# Altium schematic sheet Resistor Bank.SchDoc
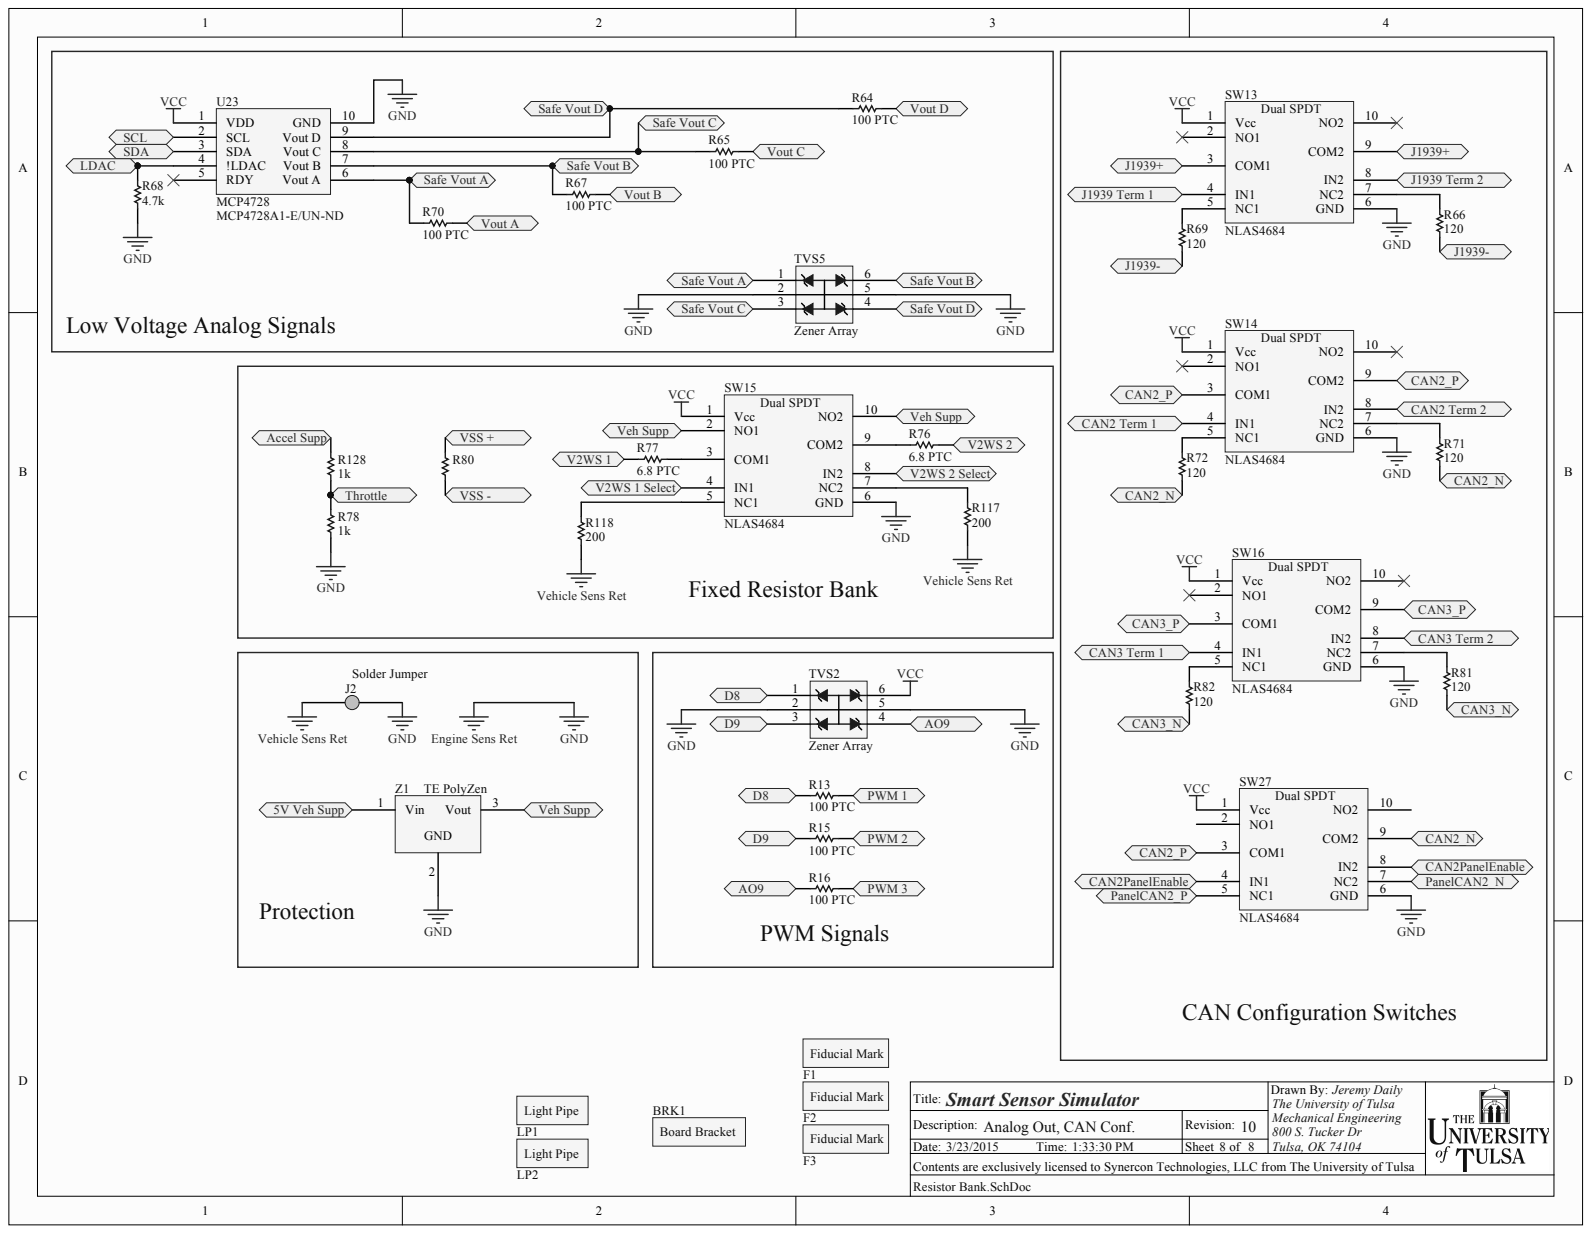
[diagram p0: Resistor Bank.SchDoc]

## Parts
BRK1  Bracket  [Keystone 7774]
F1  Fiducials  [Fiducial]  (value not drawn)
F2  Fiducials  [Fiducial]  (value not drawn)
F3  Fiducials  [Fiducial]  (value not drawn)
J2  Solder Jumper  [Solder Jumper]
LP1  Light Pipe  [Light Pipe]
LP2  Light Pipe  [Light Pipe]
R13  100 PTC  [0603]
R15  100 PTC  [0603]
R16  100 PTC  [0603]
R64  100 PTC  [0603]
R65  100 PTC  [0603]
R66  120  [0805]
R67  100 PTC  [0603]
R68  4.7k  [0805]
R69  120  [0805]
R70  100 PTC  [0603]
R71  120  [0805]
R72  120  [0805]
R76  6.8 PTC  [0805]
R77  6.8 PTC  [0805]
R78  1k  [0603]
R80  1.5k  [0603]  (value not drawn)
R81  120  [0805]
R82  120  [0805]
R117  200  [0805]
R118  200  [0805]
R128  1k  [0603]
SW13  NLAS4684  [ONSC-MICRO-10-846B-03_V]
SW14  NLAS4684  [ONSC-MICRO-10-846B-03_V]
SW15  NLAS4684  [ONSC-MICRO-10-846B-03_V]
SW16  NLAS4684  [ONSC-MICRO-10-846B-03_V]
SW27  NLAS4684  [ONSC-MICRO-10-846B-03_V]
TVS2  Zener Array  [SOT23-6]
TVS5  Zener Array  [SOT23-6]
U23  MCP4728  Supplier Part Number 1: MCP4728A1-E/UN-ND  [MSOP-UN10_M]
Z1  TE PolyZen  [ZEN LS]

## Ports
5V VEH SUPP, ACCEL SUPP, AO9, CAN2 TERM 1, CAN2 TERM 2, CAN2_N, CAN2_P, CAN2PANELENABLE, CAN3 TERM 1, CAN3 TERM 2, CAN3_N, CAN3_P, D8, D9, J1939 TERM 1, J1939 TERM 2, J1939+, J1939-, LDAC, PANELCAN2_N, PANELCAN2_P, PWM 1, PWM 2, PWM 3, SAFE VOUT A, SAFE VOUT B, SAFE VOUT C, SAFE VOUT D, SCL, SDA, THROTTLE, V2WS 1, V2WS 1 SELECT, V2WS 2, V2WS 2 SELECT, VEH SUPP, VOUT A, VOUT B, VOUT C, VOUT D, VSS +, VSS -

## Nets
ENGINE SENS RET: J2.1 R68.1 R78.1 SW13.6(GND) SW14.6(GND) SW15.6(GND) SW16.6(GND) SW27.6(GND) TVS2.2 TVS2.5 TVS5.2 TVS5.5 U23.10(GND) Z1.2
VCC: SW13.1(Vcc) SW14.1(Vcc) SW15.1(Vcc) SW16.1(Vcc) SW27.1(Vcc) TVS2.6 U23.1(VDD)
VEHICLE SENS RET: J2.2 R117.1 R118.1
AO9: R16.2 TVS2.4 ; port AO9
CAN2_N: R71.1 R72.1 SW27.9(COM2) ; port CAN2_N
CAN2_P: SW14.3(COM1) SW14.9(COM2) SW27.3(COM1) ; port CAN2_P
CAN3_N: R81.1 R82.1 ; port CAN3_N
CAN3_P: SW16.3(COM1) SW16.9(COM2) ; port CAN3_P
D8: R13.2 TVS2.1 ; port D8
D9: R15.2 TVS2.3 ; port D9
LDAC: R68.2 U23.4(!LDAC) ; port LDAC
PWM 1: R13.1 ; port PWM 1
PWM 2: R15.1 ; port PWM 2
PWM 3: R16.1 ; port PWM 3
SCL: U23.2(SCL) ; port SCL
SDA: U23.3(SDA) ; port SDA
V2WS 1: R77.2 ; port V2WS 1
V2WS 2: R76.1 ; port V2WS 2
NetR64_1: R64.1 ; port VOUT D
NetR64_2: R64.2 TVS5.4 U23.9(Vout_D) ; port SAFE VOUT D
NetR65_1: R65.1 ; port VOUT C
NetR65_2: R65.2 TVS5.3 U23.8(Vout_C) ; port SAFE VOUT C
NetR66_1: R66.1 R69.1
NetR66_2: R66.2 SW13.7(NC2)
NetR67_1: R67.1 ; port VOUT B
NetR67_2: R67.2 TVS5.6 U23.7(Vout_B) ; port SAFE VOUT B
NetR69_2: R69.2 SW13.5(NC1)
NetR70_1: R70.1 ; port VOUT A
NetR70_2: R70.2 TVS5.1 U23.6(Vout_A) ; port SAFE VOUT A
NetR71_2: R71.2 SW14.7(NC2)
NetR72_2: R72.2 SW14.5(NC1)
NetR76_2: R76.2 SW15.9(COM2)
NetR77_1: R77.1 SW15.3(COM1)
NetR78_2: R78.2 R128.1 ; port THROTTLE
NetR80_1: R80.1
NetR80_2: R80.2
NetR81_2: R81.2 SW16.7(NC2)
NetR82_2: R82.2 SW16.5(NC1)
NetR117_2: R117.2 SW15.7(NC2)
NetR118_2: R118.2 SW15.5(NC1)
NetR128_2: R128.2 ; port ACCEL SUPP
NetSW13_3: SW13.3(COM1) SW13.9(COM2)
NetSW13_4: SW13.4(IN1) ; port J1939 TERM 1
NetSW13_8: SW13.8(IN2) ; port J1939 TERM 2
NetSW14_4: SW14.4(IN1) ; port CAN2 TERM 1
NetSW14_8: SW14.8(IN2) ; port CAN2 TERM 2
NetSW15_2: SW15.2(NO1) SW15.10(NO2) Z1.3 ; port VEH SUPP
NetSW15_4: SW15.4(IN1) ; port V2WS 1 SELECT
NetSW15_8: SW15.8(IN2) ; port V2WS 2 SELECT
NetSW16_4: SW16.4(IN1) ; port CAN3 TERM 1
NetSW16_8: SW16.8(IN2) ; port CAN3 TERM 2
NetSW27_4: SW27.4(IN1) SW27.8(IN2) ; port CAN2PANELENABLE
NetSW27_5: SW27.5(NC1) ; port PANELCAN2_P
NetSW27_7: SW27.7(NC2) ; port PANELCAN2_N
NetZ1_1: Z1.1 ; port 5V VEH SUPP

Not connected: SW13 2(NO1) 10(NO2); SW14 2(NO1) 10(NO2); SW16 2(NO1) 10(NO2); SW27 2(NO1) 10(NO2); U23 5(RDY)

## Library data of the parts
BRK1 = Bracket: TERM SCREW SNAP-IN PC MNT; Manufacturer: Keystone Electronics; PartNumber: 7774; Component Kind: Standard; Library Name: SSS Schematic Library.SchLib; Supplier 1: Digi-Key; Supplier Part Number 1: 7774K-ND
F1-F3 = Fiducials: Remove from BOM; Component Kind: Standard; Library Name: SSS Schematic Library.SchLib
J2 = Solder Jumper: Remove from BOM; Component Kind: Standard; Library Name: SSS Schematic Library.SchLib
LP1 LP2 = Light Pipe: Remove from BOM; Component Kind: Standard; Library Name: SSS Schematic Library.SchLib
R13 = SMD Resistor: THERMISTOR PTC 100 OHM SMD; Manufacturer: Panasonic Electronic Components; PartNumber: PRG18BB101MB1RB; Component Kind: Standard; Library Name: SSS Schematic Library.SchLib; Supplier 1: Digi-Key; Supplier Part Number 1: 490-2469-1-ND
R15 R16 R64 R65 R67 R70 = SMD Resistor: THERMISTOR PTC 100 OHM SMD; Manufacturer: Murata Electronics North America; PartNumber: PRG18BB101MB1RB; Component Kind: Standard; Library Name: SSS Schematic Library.SchLib; Supplier 1: Digi-Key; Supplier Part Number 1: 490-2469-1-ND
R66 R69 R71 R72 R81 R82 = Chip Resistor: RES SMD 120 OHM 1% 1/2W 0805; Manufacturer: Panasonic Electronic Components; PartNumber: ERJ-P6WF1200V; Component Kind: Standard; Library Name: SSS Schematic Library.SchLib; Supplier 1: Digi-Key; Supplier Part Number 1: P16874CT-ND
R68 = Chip Resistor: RES SMD 4.7K OHM 1% 1/8W 0805; Manufacturer: Panasonic Electronic Components; PartNumber: ERJ-6ENF4701V; Component Kind: Standard; Library Name: SSS Schematic Library.SchLib; Supplier 1: Digi-Key; Supplier Part Number 1: P4.70KCCT-ND
R76 R77 = SMD Resistor: THERMISTOR PTC 6.8 OHM SMD; Manufacturer: Murata Electronics North America; PartNumber: PRG21BC6R8MM1RA; Component Kind: Standard; Library Name: SSS Schematic Library.SchLib; Supplier 1: Digi-Key; Supplier Part Number 1: 490-4673-1-ND
R78 R128 = SMD Resistor: RES SMD 1K OHM 1% 1/10W 0603; Manufacturer: Panasonic Electronic Components; PartNumber: ERJ-3EKF1001V; Component Kind: Standard; Library Name: SSS Schematic Library.SchLib; Supplier 1: Digi-Key; Supplier Part Number 1: P1.00KHCT-ND
R80 = SMD Resistor: RES SMD 1.5K OHM 1% 1/10W 0603; Manufacturer: Panasonic Electronic Components; PartNumber: ERJ-3EKF1501V; Component Kind: Standard; Library Name: SSS Schematic Library.SchLib; Supplier 1: Digi-Key; Supplier Part Number 1: P1.50KHCT-ND
R117 R118 = Chip Resistor: RES SMD 200 OHM 1% 1/2W 0805; Manufacturer: Panasonic Electronic Components; PartNumber: ERJ-P06F2000V; Component Kind: Standard; Library Name: SSS Schematic Library.SchLib; Supplier 1: Digi-Key; Supplier Part Number 1: P16064CT-ND
SW13-SW16 SW27 = Dual SPDT: IC SWITCH DUAL SPDT MICRO10; Manufacturer: ON Semiconductor; PartNumber: NLAS4684MR2G; Component Kind: Standard; Library Name: SSS Schematic Library.SchLib; Mounting Technology: Surface Mount; Supplier 1: Digi-Key; Supplier Part Number 1: NLAS4684MR2GOSCT-ND
TVS2 TVS5 = TVS Diode Array: TVS DIODE 5VWM 6.8VC SOT23; Manufacturer: Wurth Electronics Inc; PartNumber: 82402304; Component Kind: Standard; Library Name: SSS Schematic Library.SchLib; Supplier 1: Digi-Key; Supplier Part Number 1: 732-4476-1-ND
U23 = MCP4728 DAC: 12-Bit, Quad Digital-to-Analog Converter with EEPROM Memory; Manufacturer: Microchip; PartNumber: MCP4728A1-E/UN; Component Kind: Standard; Library Name: SSS Schematic Library.SchLib; Supplier 1: Digi-Key
Z1 = PolyZen: Polymer Protected Zener Diode; Manufacturer: TE Connectivity; PartNumber: ZEN056V075A48LS; Component Kind: Standard; Library Name: SSS Schematic Library.SchLib; Supplier 1: Digi-Key; Supplier 2: Digi-Key; Supplier Part Number 1: ZEN056V075A48LSCT-ND; Supplier Part Number 2: ZEN132V075A48LSCT-ND
